# Altium schematic sheet Inresol_grid_inverter_L3.SchDoc(Inresol_grid_inverter_L3)
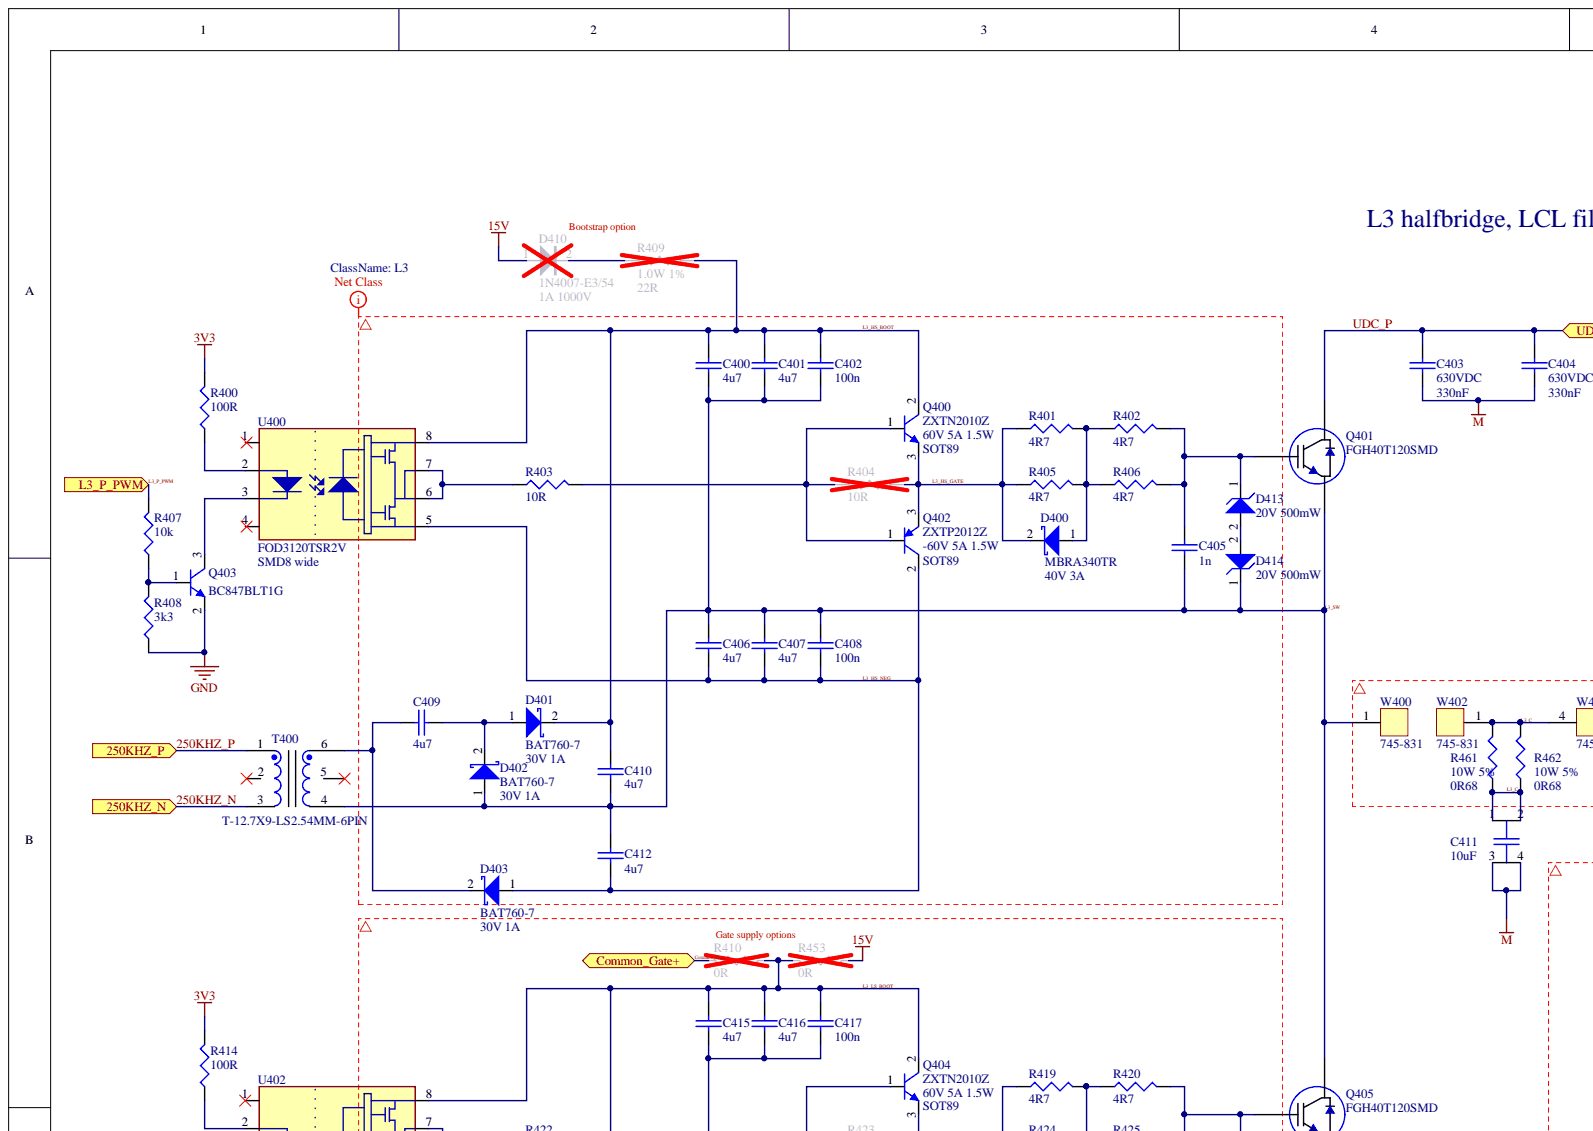
[diagram p0: Inresol_grid_inverter_L3.SchDoc, top left]
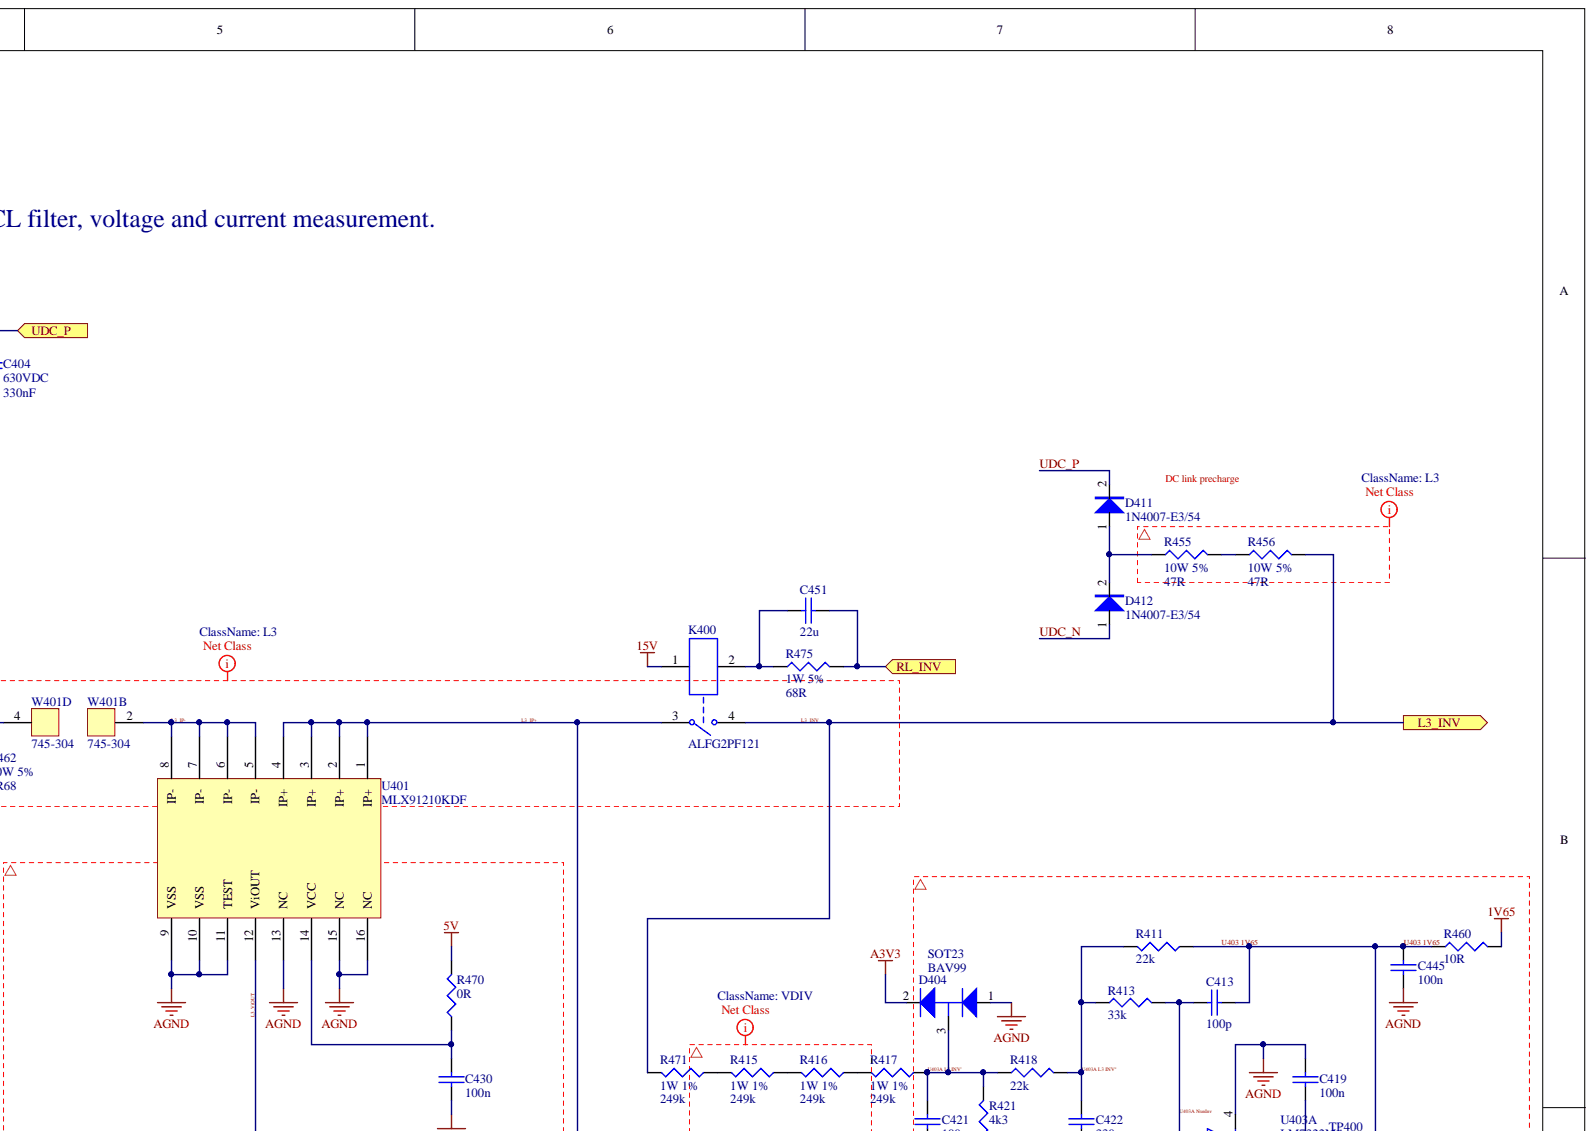
[diagram p1: Inresol_grid_inverter_L3.SchDoc, top right]
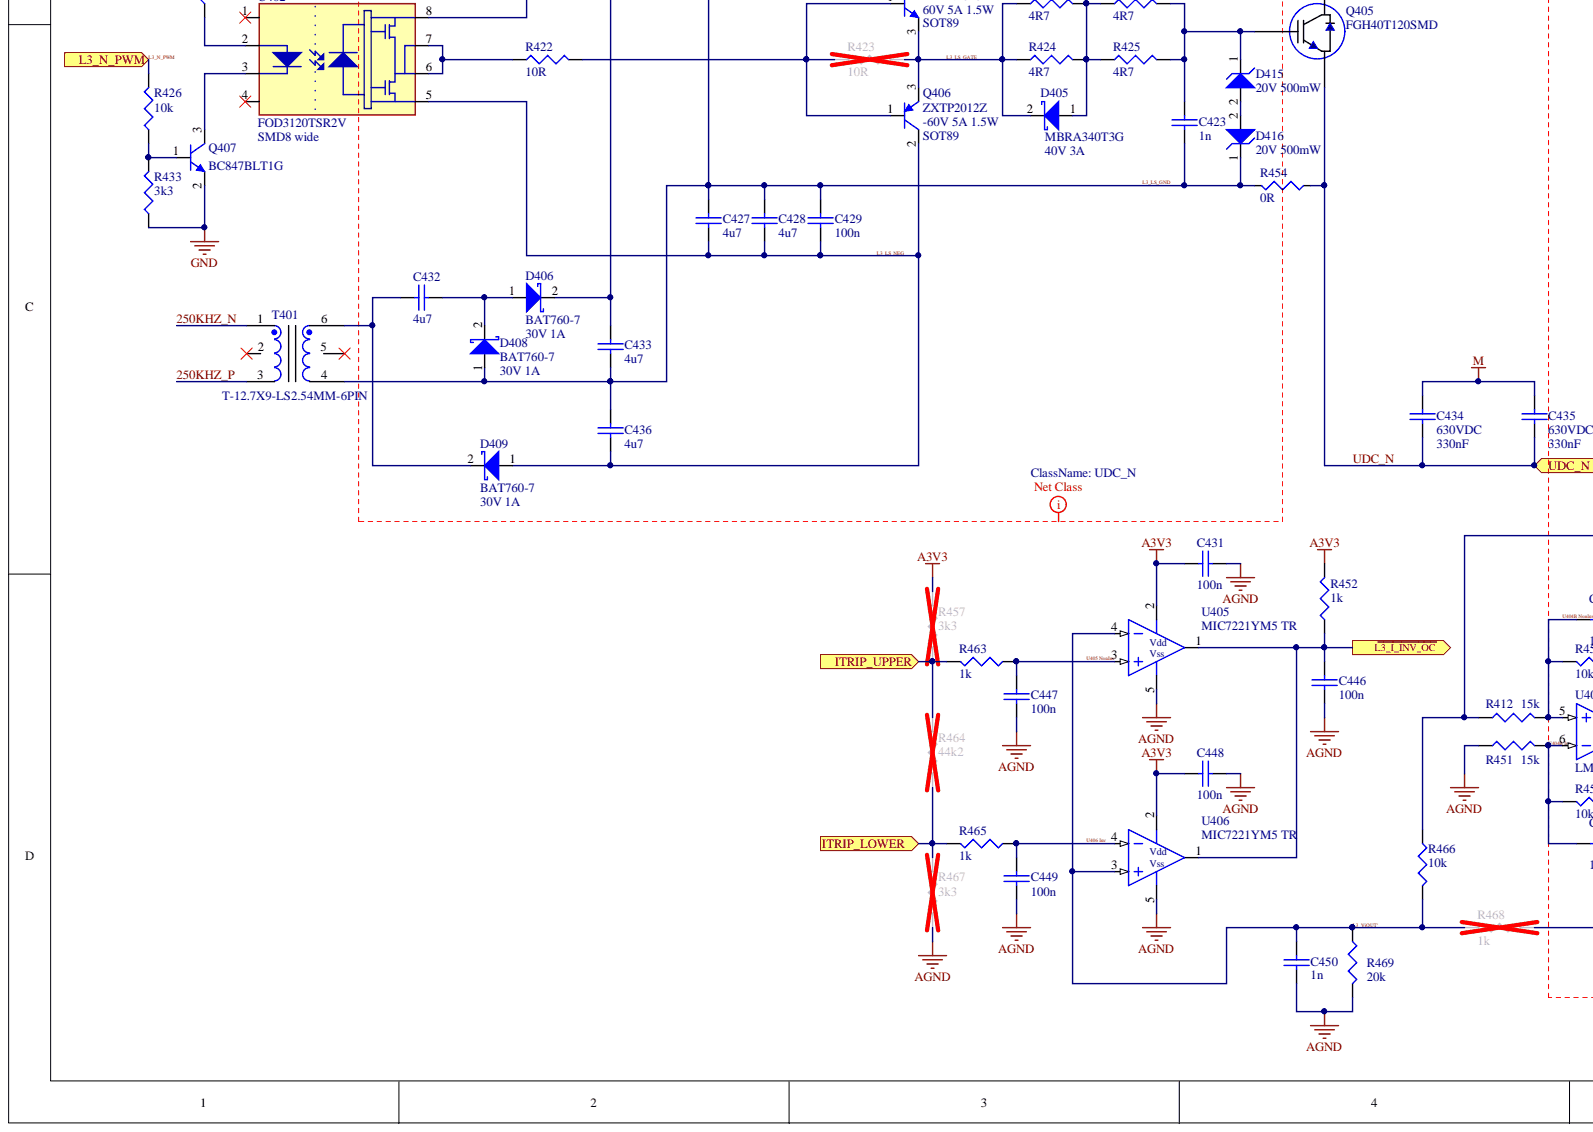
[diagram p2: Inresol_grid_inverter_L3.SchDoc, bottom left]
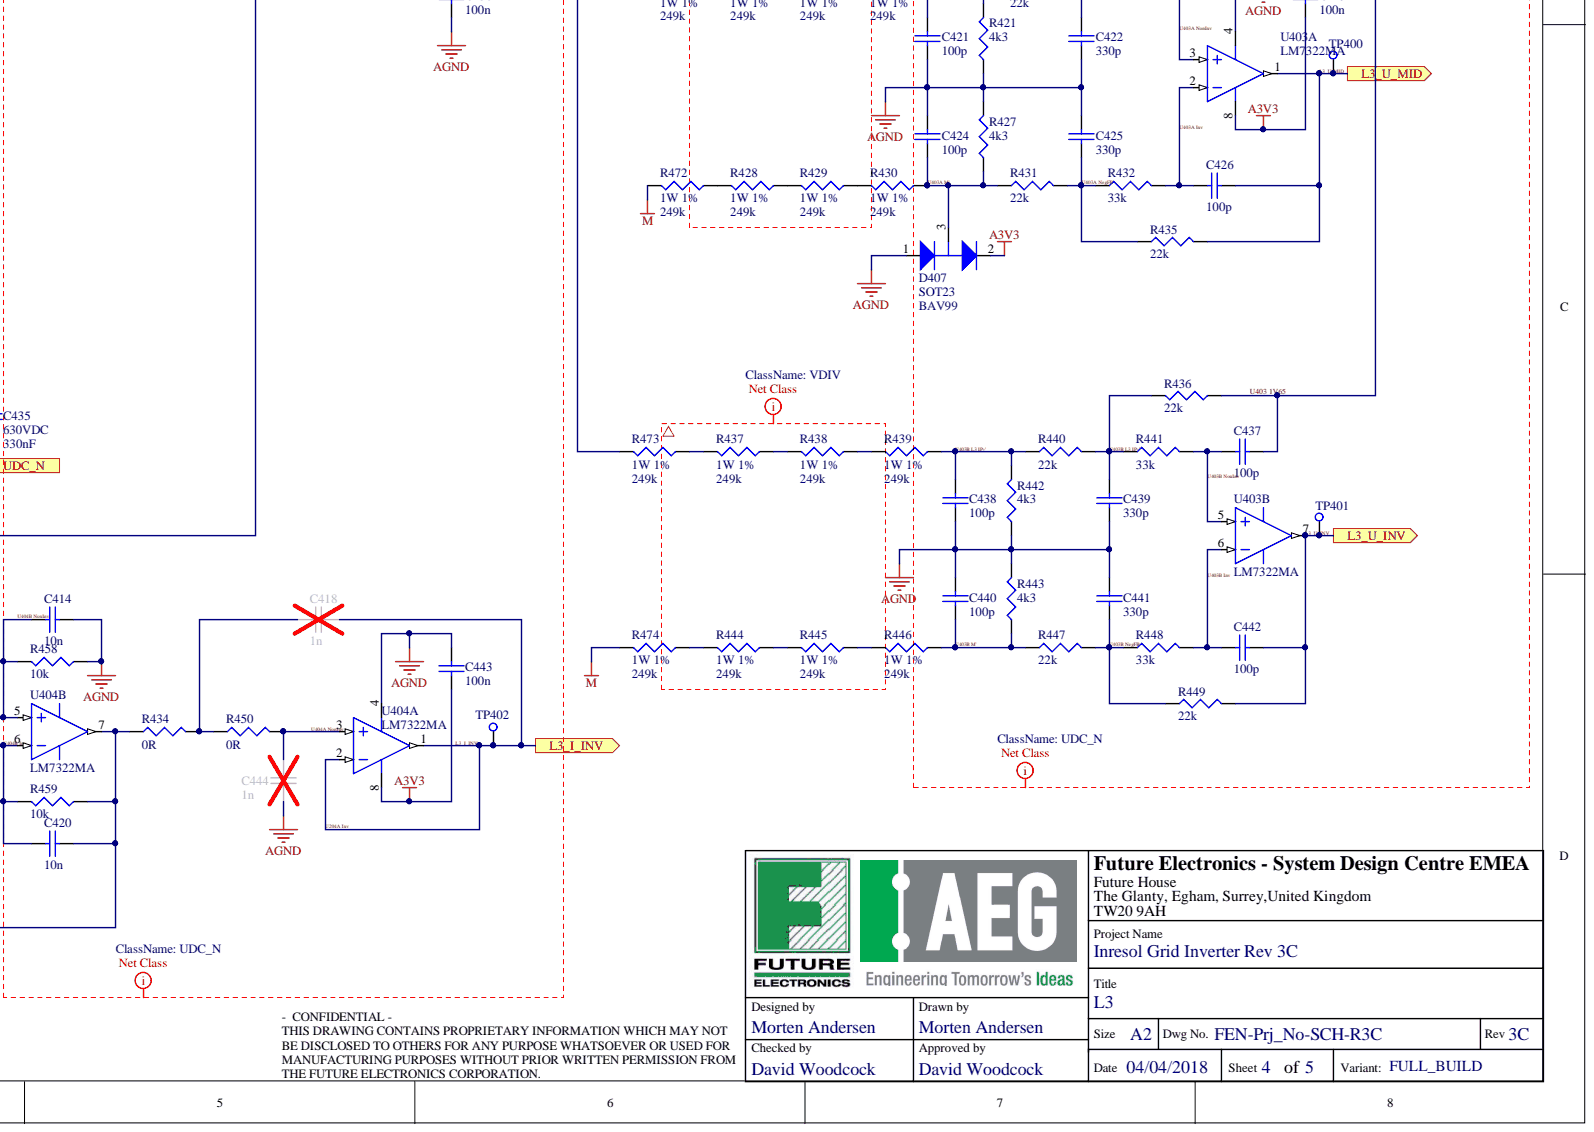
[diagram p3: Inresol_grid_inverter_L3.SchDoc, bottom right]

## Parts
C400  value 4u7  [1206]  (hidden comment: C-4.7uF-50V-Cer-1206-emea-8-10-15)
C401  value 4u7  [1206]  (hidden comment: C-4.7uF-50V-Cer-1206-emea-8-10-15)
C402  value 100n  [0603]  (hidden comment: C-100nF-50V-Cer-0603)
C403  value 330nF  Rating: 630VDC  [C-18x8.5_LS15]  (hidden comment: C-330nF-630V-PolyF-17.5x8.5)
C404  value 330nF  Rating: 630VDC  [C-18x8.5_LS15]  (hidden comment: C-330nF-630V-PolyF-17.5x8.5)
C405  value 1n  [0603]  (hidden comment: C-1nF-50V-Cer-0603)
C406  value 4u7  [1206]  (hidden comment: C-4.7uF-50V-Cer-1206-emea-8-10-15)
C407  value 4u7  [1206]  (hidden comment: C-4.7uF-50V-Cer-1206-emea-8-10-15)
C408  value 100n  [0603]  (hidden comment: C-100nF-50V-Cer-0603)
C409  value 4u7  [1206]  (hidden comment: C-4.7uF-50V-Cer-1206-emea-8-10-15)
C410  value 4u7  [1206]  (hidden comment: C-4.7uF-50V-Cer-1206-emea-8-10-15)
C411  value 10uF  (hidden comment: C-10uF-275V-PolyF-C-43x21.5)
C412  value 4u7  [1206]  (hidden comment: C-4.7uF-50V-Cer-1206-emea-8-10-15)
C413  value 100p  [0603]  (hidden comment: C-100pF-25V-Cer-0603)
C414  value 10n  [0603]  (hidden comment: C-10nF-25V-Cer-0603)
C415  value 4u7  [1206]  (hidden comment: C-4.7uF-50V-Cer-1206-emea-8-10-15)
C416  value 4u7  [1206]  (hidden comment: C-4.7uF-50V-Cer-1206-emea-8-10-15)
C417  value 100n  [0603]  (hidden comment: C-100nF-50V-Cer-0603)
C418  value 1n  [0603]  (hidden comment: C-1nF-50V-Cer-0603)
C419  value 100n  [0603]  (hidden comment: C-100nF-50V-Cer-0603)
C420  value 10n  [0603]  (hidden comment: C-10nF-25V-Cer-0603)
C421  value 100p  [0603]  (hidden comment: C-100pF-25V-Cer-0603)
C422  value 330p  [0603]  (hidden comment: C-330pF-25V-Cer-0603)
C423  value 1n  [0603]  (hidden comment: C-1nF-50V-Cer-0603)
C424  value 100p  [0603]  (hidden comment: C-100pF-25V-Cer-0603)
C425  value 330p  [0603]  (hidden comment: C-330pF-25V-Cer-0603)
C426  value 100p  [0603]  (hidden comment: C-100pF-25V-Cer-0603)
C427  value 4u7  [1206]  (hidden comment: C-4.7uF-50V-Cer-1206-emea-8-10-15)
C428  value 4u7  [1206]  (hidden comment: C-4.7uF-50V-Cer-1206-emea-8-10-15)
C429  value 100n  [0603]  (hidden comment: C-100nF-50V-Cer-0603)
C430  value 100n  [0603]  (hidden comment: C-100nF-50V-Cer-0603)
C431  value 100n  [0603]  (hidden comment: C-100nF-50V-Cer-0603)
C432  value 4u7  [1206]  (hidden comment: C-4.7uF-50V-Cer-1206-emea-8-10-15)
C433  value 4u7  [1206]  (hidden comment: C-4.7uF-50V-Cer-1206-emea-8-10-15)
C434  value 330nF  Rating: 630VDC  [C-18x8.5_LS15]  (hidden comment: C-330nF-630V-PolyF-17.5x8.5)
C435  value 330nF  Rating: 630VDC  [C-18x8.5_LS15]  (hidden comment: C-330nF-630V-PolyF-17.5x8.5)
C436  value 4u7  [1206]  (hidden comment: C-4.7uF-50V-Cer-1206-emea-8-10-15)
C437  value 100p  [0603]  (hidden comment: C-100pF-25V-Cer-0603)
C438  value 100p  [0603]  (hidden comment: C-100pF-25V-Cer-0603)
C439  value 330p  [0603]  (hidden comment: C-330pF-25V-Cer-0603)
C440  value 100p  [0603]  (hidden comment: C-100pF-25V-Cer-0603)
C441  value 330p  [0603]  (hidden comment: C-330pF-25V-Cer-0603)
C442  value 100p  [0603]  (hidden comment: C-100pF-25V-Cer-0603)
C443  value 100n  [0603]  (hidden comment: C-100nF-50V-Cer-0603)
C444  value 1n  [0603]  (hidden comment: C-1nF-50V-Cer-0603)
C445  value 100n  [0603]  (hidden comment: C-100nF-50V-Cer-0603)
C446  value 100n  [0603]  (hidden comment: C-100nF-50V-Cer-0603)
C447  value 100n  [0603]  (hidden comment: C-100nF-50V-Cer-0603)
C448  value 100n  [0603]  (hidden comment: C-100nF-50V-Cer-0603)
C449  value 100n  [0603]  (hidden comment: C-100nF-50V-Cer-0603)
C450  value 1n  [0603]  (hidden comment: C-1nF-50V-Cer-0603)
C451  value 22u  [1210]  (hidden comment: C-22uF-25V-Cer-1210)
D400  Future PN (TR): MBRA340TR  Rating: 40V 3A  [SMA]  (hidden comment: D-SMA-MBRA340T3G)
D401  MFR PN: BAT760-7  [SOD323]  (hidden comment: D-SOD323-BAT760-7)
D402  MFR PN: BAT760-7  Rating: 30V 1A  [SOD323]  (hidden comment: D-SOD323-BAT760-7)
D403  MFR PN: BAT760-7  Rating: 30V 1A  [SOD323]  (hidden comment: D-SOD323-BAT760-7)
D404  value BAV99  [SOT23]  (hidden comment: D-SOT23-BAV99)
D405  MFR PN: MBRA340T3G  Rating: 40V 3A  [SMA]  (hidden comment: D-SMA-MBRA340T3G)
D406  MFR PN: BAT760-7  [SOD323]  (hidden comment: D-SOD323-BAT760-7)
D407  value BAV99  [SOT23]  (hidden comment: D-SOT23-BAV99)
D408  MFR PN: BAT760-7  Rating: 30V 1A  [SOD323]  (hidden comment: D-SOD323-BAT760-7)
D409  MFR PN: BAT760-7  Rating: 30V 1A  [SOD323]  (hidden comment: D-SOD323-BAT760-7)
D410  MFR PN: 1N4007-E3/54  Rating: 1A 1000V  [DO-41]  (hidden comment: D-DO41-1N4007)
D411  MFR PN: 1N4007-E3/54  [DO-41]  (hidden comment: D-DO41-1N4007)
D412  MFR PN: 1N4007-E3/54  [DO-41]  (hidden comment: D-DO41-1N4007)
D413  Rating: 20V 500mW  [SOD123]  (hidden comment: D-SOD123-MMSZ5250B-20V-EMEA-19-05-16)
D414  Rating: 20V 500mW  [SOD123]  (hidden comment: D-SOD123-MMSZ5250B-20V-EMEA-19-05-16)
D415  Rating: 20V 500mW  [SOD123]  (hidden comment: D-SOD123-MMSZ5250B-20V-EMEA-19-05-16)
D416  Rating: 20V 500mW  [SOD123]  (hidden comment: D-SOD123-MMSZ5250B-20V-EMEA-19-05-16)
K400  MFR PN: ALFG2PF121  (hidden comment: K-Panasonic-Relay-SPST-NO-35A-12V-EMEA-07-09-2017)
Q400  value ZXTN2010Z  Future PN (CT): ZXTN2010Z  [SOT89]  (hidden comment: Q-SOT89-ZXTN2010Z)
Q401  MFR PN: FGH40T120SMD  [TO247]  (hidden comment: Q-TO-247-FGH40T120)
Q402  value ZXTP2012Z  MFR PN: ZXTP2012Z  [SOT89]  (hidden comment: Q-SOT89-ZXTP2012Z)
Q403  value BC847BLT1G  MFR PN: BC847BLT1G  [SOT23]  (hidden comment: Q-SOT23-BC847BLT1G)
Q404  value ZXTN2010Z  Future PN (CT): ZXTN2010Z  [SOT89]  (hidden comment: Q-SOT89-ZXTN2010Z)
Q405  MFR PN: FGH40T120SMD  [TO247]  (hidden comment: Q-TO-247-FGH40T120)
Q406  value ZXTP2012Z  MFR PN: ZXTP2012Z  [SOT89]  (hidden comment: Q-SOT89-ZXTP2012Z)
Q407  value BC847BLT1G  MFR PN: BC847BLT1G  [SOT23]  (hidden comment: Q-SOT23-BC847BLT1G)
R400  value 100R  [0603]  (hidden comment: R-100R-100mW-0603)
R401  value 4R7  [2512]  (hidden comment: R-4.7R-1W-2512)
R402  value 4R7  [2512]  (hidden comment: R-4.7R-1W-2512)
R403  value 10R  [2512]  (hidden comment: R-10R-1W-2512-EMEA-07-09-2017)
R404  value 10R  [2512]  (hidden comment: R-10R-1W-2512-EMEA-07-09-2017)
R405  value 4R7  [2512]  (hidden comment: R-4.7R-1W-2512)
R406  value 4R7  [2512]  (hidden comment: R-4.7R-1W-2512)
R407  value 10k  [0603]  (hidden comment: R-10k-100mW-0603)
R408  value 3k3  [0603]  (hidden comment: R-3.3k-100mW-0603)
R409  value 22R  Rating: 1.0W 1%  [2512]  (hidden comment: R-22R-1.0W-2512-EMEA)
R410  value 0R  [1206]  (hidden comment: R-0R-250mW-1206)
R411  value 22k  [0603]  (hidden comment: R-22k-100mW-0603)
R412  value 15k  [0603]  (hidden comment: R-15k-100mW-0603)
R413  value 33k  [0603]  (hidden comment: R-33k-100mW-0603)
R414  value 100R  [0603]  (hidden comment: R-100R-100mW-0603)
R415  value 249k  Rating: 1W 1%  [2512]  (hidden comment: R-249k-1W-2512)
R416  value 249k  Rating: 1W 1%  [2512]  (hidden comment: R-249k-1W-2512)
R417  value 249k  Rating: 1W 1%  [2512]  (hidden comment: R-249k-1W-2512)
R418  value 22k  [0603]  (hidden comment: R-22k-100mW-0603)
R419  value 4R7  [2512]  (hidden comment: R-4.7R-1W-2512)
R420  value 4R7  [2512]  (hidden comment: R-4.7R-1W-2512)
R421  value 4k3  [0603]  (hidden comment: R-4.3k-100mW-0603)
R422  value 10R  [2512]  (hidden comment: R-10R-1W-2512-EMEA-07-09-2017)
R423  value 10R  [2512]  (hidden comment: R-10R-1W-2512-EMEA-07-09-2017)
R424  value 4R7  [2512]  (hidden comment: R-4.7R-1W-2512)
R425  value 4R7  [2512]  (hidden comment: R-4.7R-1W-2512)
R426  value 10k  [0603]  (hidden comment: R-10k-100mW-0603)
R427  value 4k3  [0603]  (hidden comment: R-4.3k-100mW-0603)
R428  value 249k  Rating: 1W 1%  [2512]  (hidden comment: R-249k-1W-2512)
R429  value 249k  Rating: 1W 1%  [2512]  (hidden comment: R-249k-1W-2512)
R430  value 249k  Rating: 1W 1%  [2512]  (hidden comment: R-249k-1W-2512)
R431  value 22k  [0603]  (hidden comment: R-22k-100mW-0603)
R432  value 33k  [0603]  (hidden comment: R-33k-100mW-0603)
R433  value 3k3  [0603]  (hidden comment: R-3.3k-100mW-0603)
R434  value 0R  [0603]  (hidden comment: R-0R-100mW-0603)
R435  value 22k  [0603]  (hidden comment: R-22k-100mW-0603)
R436  value 22k  [0603]  (hidden comment: R-22k-100mW-0603)
R437  value 249k  Rating: 1W 1%  [2512]  (hidden comment: R-249k-1W-2512)
R438  value 249k  Rating: 1W 1%  [2512]  (hidden comment: R-249k-1W-2512)
R439  value 249k  Rating: 1W 1%  [2512]  (hidden comment: R-249k-1W-2512)
R440  value 22k  [0603]  (hidden comment: R-22k-100mW-0603)
R441  value 33k  [0603]  (hidden comment: R-33k-100mW-0603)
R442  value 4k3  [0603]  (hidden comment: R-4.3k-100mW-0603)
R443  value 4k3  [0603]  (hidden comment: R-4.3k-100mW-0603)
R444  value 249k  Rating: 1W 1%  [2512]  (hidden comment: R-249k-1W-2512)
R445  value 249k  Rating: 1W 1%  [2512]  (hidden comment: R-249k-1W-2512)
R446  value 249k  Rating: 1W 1%  [2512]  (hidden comment: R-249k-1W-2512)
R447  value 22k  [0603]  (hidden comment: R-22k-100mW-0603)
R448  value 33k  [0603]  (hidden comment: R-33k-100mW-0603)
R449  value 22k  [0603]  (hidden comment: R-22k-100mW-0603)
R450  value 0R  [0603]  (hidden comment: R-0R-100mW-0603)
R451  value 15k  [0603]  (hidden comment: R-15k-100mW-0603)
R452  value 1k  [0603]  (hidden comment: R-1k-100mW-0603)
R453  value 0R  [1206]  (hidden comment: R-0R-250mW-1206)
R454  value 0R  [1206]  (hidden comment: R-0R-250mW-1206)
R455  value 47R  Rating: 10W 5%  [13x9]  (hidden comment: R-47R-10W-13x9x51-EMEA-19-01-2016)
R456  value 47R  Rating: 10W 5%  [13x9]  (hidden comment: R-47R-10W-13x9x51-EMEA-19-01-2016)
R457  value 3k3  [0603]  (hidden comment: R-3.3k-100mW-0603)
R458  value 10k  [0603]  (hidden comment: R-10k-100mW-0603)
R459  value 10k  [0603]  (hidden comment: R-10k-100mW-0603)
R460  value 10R  [0603]  (hidden comment: R-10R-100mW-0603)
R461  value 0R68  Rating: 10W 5%  [13x9]  (hidden comment: R-0R68-10W-13x9x51-EMEA-07-09-2017)
R462  value 0R68  Rating: 10W 5%  [13x9]  (hidden comment: R-0R68-10W-13x9x51-EMEA-07-09-2017)
R463  value 1k  [0603]  (hidden comment: R-1k-100mW-0603)
R464  value 44k2  [0603]  (hidden comment: R-44.2k-100mW -0603)
R465  value 1k  [0603]  (hidden comment: R-1k-100mW-0603)
R466  value 10k  [0603]  (hidden comment: R-10k-100mW-0603)
R467  value 3k3  [0603]  (hidden comment: R-3.3k-100mW-0603)
R468  value 1k  [0603]  (hidden comment: R-1k-100mW-0603)
R469  value 20k  [0603]  (hidden comment: R-20k-100mW-0603)
R470  value 0R  [0603]  (hidden comment: R-0R-100mW-0603)
R471  value 249k  Rating: 1W 1%  [2512]  (hidden comment: R-249k-1W-2512)
R472  value 249k  Rating: 1W 1%  [2512]  (hidden comment: R-249k-1W-2512)
R473  value 249k  Rating: 1W 1%  [2512]  (hidden comment: R-249k-1W-2512)
R474  value 249k  Rating: 1W 1%  [2512]  (hidden comment: R-249k-1W-2512)
R475  value 68R  Rating: 1W 5%  [2512]  (hidden comment: R-68R-1W-2512)
T400  Custom  [T-12.7X9-LS2.54MM-6PIN]  (value not drawn)
T401  Custom  [T-12.7X9-LS2.54MM-6PIN]  (value not drawn)
TP400  TP-TEST POINT-LOOP WT-EMEA-15-10-15  [SMD test point round]  (value not drawn)  (no BOM)
TP401  TP-TEST POINT-LOOP WT-EMEA-15-10-15  [SMD test point round]  (value not drawn)  (no BOM)
TP402  TP-TEST POINT-LOOP WT-EMEA-15-10-15  [SMD test point round]  (value not drawn)  (no BOM)
U400  value FOD3120TSR2V  MFR PN: FOD3120TSR2V  [SMD8 wide]  (hidden comment: U-SMD8 wide -FOD3120SD)
U401  Rating: MLX91210KDF  [SOIC16W]  (hidden comment: U- SOICW 16PIN-MLX91210KDF-EMEA-24-08-2017)
U402  value FOD3120TSR2V  MFR PN: FOD3120TSR2V  [SMD8 wide]  (hidden comment: U-SMD8 wide -FOD3120SD)
U403  value LM7322MA  MFR PN: LM7322MA  [SO8]  (hidden comment: U-SOIC8-LM7322MA-EMEA)  (parts A,B)
U404  value LM7322MA  MFR PN: LM7322MA  [SO8]  (hidden comment: U-SOIC8-LM7322MA-EMEA)  (parts A,B)
U405  U-SOT23_5-MIC7221YM5 TR  [SOT23_5]  (value not drawn)
U406  U-SOT23_5-MIC7221YM5 TR  [SOT23_5]  (value not drawn)
W400  J-WAGO_745-831  [745-831]  (value not drawn)
W401  J-WAGO_745-304_blocks  [745-304]  (value not drawn)  (parts B,D)
W402  J-WAGO_745-831  [745-831]  (value not drawn)

## Ports
250KHZ_N, 250KHZ_P, COMMON_GATE+, ITRIP_LOWER, ITRIP_UPPER, L3_I_INV, L3_INV, L3_N_PWM, L3_P_PWM, L3_U_INV, L3_U_MID, RL_INV, UDC_N, UDC_P, ~{L3_I_INV_OC}

## Nets
1V65: R460.2
3V3: R400.2 R414.2
5V: R470.2
15V: D410.1 K400.1 R453.1
A3V3: C419.2 C431.1 C443.2 C448.1 D404.2 D407.2 R452.2 R457.2 U403.8 U404.8 U405.2 U406.2
AGND: C414.2 C419.1 C421.2 C422.2 C424.1 C425.1 C430.2 C431.2 C438.2 C439.2 C440.1 C441.1 C443.1 C444.2 C445.2 C446.1 C447.1 C448.2 C449.1 C450.1 D404.1 D407.1 R421.1 R427.2 R442.1 R443.2 R451.1 R458.1 R467.1 R469.2 U401.9(VSS) U401.10(VSS) U401.11(TEST) U401.13(NC) U401.15(NC) U401.16(NC) U403.4 U404.4 U405.5 U406.5
GND: Q403.2 Q407.2 R408.1 R433.1
M: C403.2 C404.2 C411.3 C411.4 C434.2 C435.2 R472.2 R474.2
250KHZ_N: T400.3 T401.1 ; port 250KHZ_N
250KHZ_P: T400.1 T401.3 ; port 250KHZ_P
COMMON_GATE+: R410.2 ; port COMMON_GATE+
ITRIP_LOWER: R464.1 R465.2 R467.2 ; port ITRIP_LOWER
ITRIP_UPPER: R457.1 R463.2 R464.2 ; port ITRIP_UPPER
L3_C: R461.2 R462.2 W401.4 W402.1
L3_C_: C411.1 C411.2 R461.1 R462.1
L3_HS_BOOT: C400.2 C401.2 C402.1 C410.2 D401.2 Q400.2 R409.2 U400.8
L3_HS_GATE: D400.2 Q400.3 Q402.3 R401.1 R404.2 R405.1
L3_HS_NEG: C406.1 C407.1 C408.2 C412.1 D403.1 Q402.2 U400.5
L3_I_INV: C418.2 TP402.1 U404.1 U404.2 ; port L3_I_INV
L3_INV: K400.4 R456.2 R471.2 ; port L3_INV
L3_IP+: K400.3 R473.2 U401.1(IP+) U401.2(IP+) U401.3(IP+) U401.4(IP+)
L3_IP-: U401.5(IP-) U401.6(IP-) U401.7(IP-) U401.8(IP-) W401.2
L3_LS_BOOT: C415.2 C416.2 C417.1 C433.2 D406.2 Q404.2 R410.1 R453.2 U402.8
L3_LS_GATE: D405.2 Q404.3 Q406.3 R419.1 R423.2 R424.1
L3_LS_GND: C415.1 C416.1 C417.2 C423.2 C427.2 C428.2 C429.1 C433.1 C436.2 D408.1 D416.1 R454.2 T401.4
L3_LS_NEG: C427.1 C428.1 C429.2 C436.1 D409.1 Q406.2 U402.5
L3_N_PWM: R426.2 ; port L3_N_PWM
L3_P_PWM: R407.2 ; port L3_P_PWM
L3_SW: C400.1 C401.1 C402.2 C405.2 C406.2 C407.2 C408.1 C410.1 C412.2 D402.1 D414.1 Q401.3 Q405.2 T400.4 W400.1
L3_U_INV: C442.1 R449.2 TP401.1 U403.7 ; port L3_U_INV
L3_U_MID: C426.1 R435.2 TP400.1 U403.1 ; port L3_U_MID
L3_VIOUT: R412.1 R466.1 U401.12(ViOUT)
L3_VIOUT': C450.2 R466.2 R468.1 R469.1 U405.4 U406.3
~{L3_I_INV_OC}: C446.2 R452.1 U405.1 U406.1 ; port ~{L3_I_INV_OC}
RL_INV: C451.2 R475.2 ; port RL_INV
U403 1V65: C413.1 C437.1 C445.1 R411.1 R436.1 R460.1
U403A INV: C426.2 R432.2 U403.2
U403A L3 INV': C421.1 D404.3 R417.1 R418.1 R421.2
U403A L3 INV'': C422.1 R411.2 R413.1 R418.2
U403A M': C424.2 D407.3 R427.1 R430.1 R431.1
U403A NEGFB: C425.2 R431.2 R432.1 R435.1
U403A NONINV: C413.2 R413.2 U403.3
U403B INV: C442.2 R448.2 U403.6
U403B L3 IP+': C438.1 R439.1 R440.1 R442.2
U403B L3 IP+'': C439.1 R436.2 R440.2 R441.1
U403B M': C440.2 R443.1 R446.1 R447.1
U403B NEGFB: C441.2 R447.2 R448.1 R449.1
U403B NONINV: C437.2 R441.2 U403.5
U404A NONINV: C444.1 R450.2 U404.3
U404B INV: C420.1 R451.2 R459.2 U404.6
U404B NONINV: C414.1 R412.2 R458.2 U404.5
U405 NONINV: C447.2 R463.1 U405.3
U406 INV: C449.2 R465.1 U406.4
UDC_N: C434.1 C435.1 D412.1 Q405.3 R454.1 ; port UDC_N
UDC_P: C403.1 C404.1 D411.2 Q401.2 ; port UDC_P
NetC405_1: C405.1 D413.1 Q401.1 R402.2 R406.2
NetC409_1: C409.1 D403.2 T400.6
NetC409_2: C409.2 D401.1 D402.2
NetC418_1: C418.1 R434.2 R450.1
NetC420_2: C420.2 R434.1 R459.1 R468.2 U404.7
NetC423_1: C423.1 D415.1 Q405.1 R420.2 R425.2
NetC430_1: C430.1 R470.1 U401.14(VCC)
NetC432_1: C432.1 D409.2 T401.6
NetC432_2: C432.2 D406.1 D408.2
NetC451_1: C451.1 K400.2 R475.1
NetD400_1: D400.1 R401.2 R402.1 R405.2 R406.1
NetD405_1: D405.1 R419.2 R420.1 R424.2 R425.1
NetD410_2: D410.2 R409.1
NetD411_1: D411.1 D412.2 R455.1
NetD413_2: D413.2 D414.2
NetD415_2: D415.2 D416.2
NetQ400_1: Q400.1 Q402.1 R403.2 R404.1
NetQ403_1: Q403.1 R407.1 R408.2
NetQ403_3: Q403.3 U400.3
NetQ404_1: Q404.1 Q406.1 R422.2 R423.1
NetQ407_1: Q407.1 R426.1 R433.2
NetQ407_3: Q407.3 U402.3
NetR400_1: R400.1 U400.2
NetR403_1: R403.1 U400.6 U400.7
NetR414_1: R414.1 U402.2
NetR415_1: R415.1 R416.2
NetR415_2: R415.2 R471.1
NetR416_1: R416.1 R417.2
NetR422_1: R422.1 U402.6 U402.7
NetR428_1: R428.1 R429.2
NetR428_2: R428.2 R472.1
NetR429_1: R429.1 R430.2
NetR437_1: R437.1 R438.2
NetR437_2: R437.2 R473.1
NetR438_1: R438.1 R439.2
NetR444_1: R444.1 R445.2
NetR444_2: R444.2 R474.1
NetR445_1: R445.1 R446.2
NetR455_2: R455.2 R456.1
N00399:
N00400:
N00401:
N00402:
N00403:
N00404:
N00405:
N00406:

Not connected: T400 2 5; T401 2 5; U400 1 4; U402 1 4

## Library data of the parts
C400 C401 C406 C407 C409 C410 C412 C415 C416 C427 C428 C432 C433 C436 = C-4.7uF-50V-Cer-1206-emea-8-10-15: Cap 1206 SM X7R 50V 10%; MFR: SAMSUNG; MFR PN: CL31B475KBHNNNE; Apr'd: raised emea 8th Oct 2015; Future PN (CT): TBA; Future PN (TR): 12065C475KATM; Rating: 50V 10%; Component Kind: Standard; ComponentLink1Description: Datasheet; ComponentLink1URL: http://www.samsungsem.com/servlet/ProductSearchView?page=1&searchIdx=1&lang=kr&partnum=1&find_val=CL31B475KBHNNNE; Library Name: EMEA CAPACITORS_FEB2016.SchLib
C402 C408 C417 C419 C429-C431 C443 C445-C449 = C-100nF-50V-Cer-0603: Cap 0603 SM X7R 50V 10%; MFR: AVX; MFR PN: 06035C104K4T2A; Future PN (CT): 06035C104K4TN-CT; Future PN (TR): 06035C104K4TN; Rating: 50V 10%; Component Kind: Standard; ComponentLink1Description: Datasheet; ComponentLink1URL: http://www.avx.com/docs/Catalogs/cx7r.pdf; Library Name: EMEA CAPACITORS_FEB2016.SchLib; Verified: AD030615
C403 C404 C434 C435 = C-330nF-630V-PolyF-17.5x8.5-EMEA-07-09-2017: Cap 17.5x8.5 TH Polyprop 630VDC; MFR: VISHAY; MFR PN: BFC233820334; Apr'd: TBD; Future PN (CT): TBA; Component Kind: Standard; ComponentLink1Description: Datasheet; ComponentLink1URL: https://www.vishay.com/docs/28119/mkp3382.pdf; Future PN (TR): TBD; Library Name: Inresol_grid_inverter.SchLib
C405 C418 C423 C444 C450 = C-1nF-50V-Cer-0603: Cap 0603 SM X7R 50V 10%; MFR: VISHAY; MFR PN: VJ0603Y102KXACW1BC; Future PN (CT): 06035C102KATN-CT; Future PN (TR): 06035C102KATN; Rating: 50V 10%; Component Kind: Standard; ComponentLink1Description: Datasheet; ComponentLink1URL: http://www.vishay.com/docs/28548/vjw1bcbascomseries.pdf; Library Name: Future Capacitors.SCHLIB; Verified: AD030615
C411 = C-10uF-275V-PolyF-C-43x21.5: Cap 43x21.5 TH Polyprop 275V 5%; MFR: Vishay; MFR PN: MKP1847610274P4; Future PN (CT): TBD; Rating: 275Vac 5%; Component Kind: Standard; ComponentLink1Description: Datasheet; ComponentLink1URL: http://www.vishay.com/docs/28172/mkp1847ac.pdf; Future PN (TR): TBD; Library Name: Inresol_grid_inverter.SchLib
C413 C421 C424 C426 C437 C438 C440 C442 = C-100pF-25V-Cer-0603: Cap 0603 SM X7R 25V 10%; MFR: AVX; MFR PN: 06033C101KAT2A; Future PN (CT): 06033C101KATN-CT; Future PN (TR): 06033C101KATN; Rating: 25V 10%; Component Kind: Standard; ComponentLink1Description: Datasheet; ComponentLink1URL: http://www.avx.com/docs/Catalogs/cx7r.pdf; Library Name: Future Capacitors.SCHLIB; Verified: AD030615
C414 C420 = C-10nF-25V-Cer-0603: Cap 0603 SM X7R 25V 10%; MFR: AVX; MFR PN: 06033C103KAT2A; Future PN (CT): 06033C103KATN-CT; Future PN (TR): 06033C103KATN; Rating: 25V 10%; Component Kind: Standard; ComponentLink1Description: Datasheet; ComponentLink1URL: http://www.avx.com/docs/Catalogs/ccog.pdf; Library Name: Future Capacitors.SCHLIB
C422 C425 C439 C441 = C-330pF-25V-Cer-0603: Cap 0603 SM X7R 25V 1%; MFR: AVX; MFR PN: 06033A331FAT2A; Future PN (CT): TBD; Future PN (TR): 06033A331FATN; Rating: 25V 1%; Component Kind: Standard; ComponentLink1Description: Datasheet; ComponentLink1URL: http://www.avx.com/docs/Catalogs/ccog.pdf; Library Name: Future Capacitors.SCHLIB; Verified: AD030615
C451 = C-22uF-25V-Cer-1210: Cap 1210 SM X7R 25V 10%; MFR: MURATA; MFR PN: GRM32ER71E226KE15L; Future PN (CT): TBD; Future PN (TR): 12103C226KATM; Rating: 25V 10%; Component Kind: Standard; ComponentLink1Description: Datasheet; ComponentLink1URL: http://search.murata.co.jp/Ceramy/image/img/A01X/partnumbering_e_01.pdf; Library Name: Future Capacitors.SCHLIB; Verified: AD030615
D400 = D-SMA-MBRA340T3G: Diode Schottky 40V 3A SMD; MFR: On Semi; Apr'd: YES; Future PN (CT): MBRA340TR-CT; Component Kind: Standard; ComponentLink1Description: Datasheet; ComponentLink1URL: http://www.onsemi.com/pub_link/Collateral/MBRA340T3-D.PDF; Library Name: EMEA SEMICONDUCTORS_FEB2016.SchLib; MFR PN: MBRA340T3G
D401 D406 = D-SOD323-BAT760-7: Diode Schottky 30V 1A SMD; MFR: DIO; Future PN (CT): TBD; Rating: 30V 1A; Component Kind: Standard; ComponentLink1Description: Datasheet; ComponentLink1URL: https://www.diodes.com/assets/Datasheets/ds30498.pdf; Future PN (TR): TBD; Library Name: Inresol_grid_inverter.SchLib
D402 D403 D408 D409 = D-SOD323-BAT760-7: Diode Schottky 30V 1A SMD; MFR: DIO; Future PN (CT): TBD; Component Kind: Standard; ComponentLink1Description: Datasheet; ComponentLink1URL: https://www.diodes.com/assets/Datasheets/ds30498.pdf; Future PN (TR): TBD; Library Name: Inresol_grid_inverter.SchLib
D404 D407 = D-SOT23-BAV99: Diode, Silicon Dual connected in series SOT23; MFR: DIO; Future PN (CT): BAV99LT1-CT; Future PN (TR): BAV99-7-F; Rating: 75V 300mA; Component Kind: Standard; ComponentLink1Description: Datasheet; ComponentLink1URL: http://www.diodes.com/datasheets/ds12007.pdf; Library Name: Future Semiconductors.SCHLIB; MFR PN: BAV99-7-F
D405 = D-SMA-MBRA340T3G: Diode Schottky 40V 3A SMD; MFR: On Semi; Apr'd: YES; Future PN (CT): MBRA340TR-CT; Future PN (TR): MBRA340TR; Component Kind: Standard; ComponentLink1Description: Datasheet; ComponentLink1URL: http://www.onsemi.com/pub_link/Collateral/MBRA340T3-D.PDF; Library Name: EMEA SEMICONDUCTORS_FEB2016.SchLib
D410-D412 = D-DO41-1N4007: Diode Switching Si 1A 1000V; MFR: VISHAY; Apr'd: YES; Future PN (CT): 1N4007TR-CT; Future PN (TR): 1N4007TR; Component Kind: Standard; ComponentLink1Description: Datasheet; ComponentLink1URL: http://www.vishay.com/docs/88503/1n4001.pdf; Library Name: Future Semiconductors.SCHLIB
  D411 D412: Rating: 1A 1000V
D413-D416 = D-SOD123-MMSZ5250B-20V-EMEA-19-05-16: Diode Zener 20V 500mW; MFR: DIODES INC; Apr'd: RAISED EMEA 19-05-16; Future PN (CT): TBD; Component Kind: Standard; ComponentLink1Description: Datasheet; ComponentLink1URL: http://www.farnell.com/datasheets/1670964.pdf; Future PN (TR): TBD; Library Name: EMEA SEMICONDUCTORS_FEB2016.SchLib; MFR PN: MMSZ5250B; Signal Integrity: D Zener; Simulation: ZENER; Value: MMSZ5250B
K400 = K-Panasonic-Relay-SPST-NO-35A-12V-EMEA-07-09-2017: K-Relay, SPST-NO 35A 12VDC; Future PN (CT): TBD; Rating: SPST-NO 12VDC, 250VAC 31A; Component Kind: Standard; ComponentLink1Description: Datasheet; ComponentLink1URL: http://www3.panasonic.biz/ac/e/search_num/index.jsp?c=detail&part_no=ALFG2PF121; Future PN (TR): TBD; MFR: Panasonic; Simulation: SPSTRELAY; Library Name: Inresol_grid_inverter.SchLib
Q400 Q404 = Q-SOT89-ZXTN2010Z: Transistor NPN, 60V 5A 1.5W SOT89-EMEA29-09-2016; MFR: DIODES; Apr'd: YES; Future PN (TR): TBD; Component Kind: Standard; ComponentLink1Description: Datasheet; ComponentLink1URL: http://www.diodes.com/_files/datasheets/ZXTN2010Z.pdf; MFR PN: ZXTN2010Z; Rating: 60V 5A 1.5W; Library Name: Inresol_grid_inverter.SchLib
Q401 Q405 = Q-TO-247-FGH40T120: TRENCH IGBT-FGH40T120-EMEA28-09-2016; MFR: Fairchild; Apr'd: RAISED EMEA 20TH SEPT 2016; Future PN (CT): TBA; Rating: 1200V; Value: 40A; Component Kind: Standard; ComponentLink1Description: Datasheet; ComponentLink1URL: https://www.fairchildsemi.com/datasheets/FG/FGH40T120SMD.pdf; Future PN (TR): TBA; Library Name: Inresol_grid_inverter.SchLib
Q402 Q406 = Q-SOT89-ZXTP2012Z: Transistor PNP, -60V -5A 1.5W SOT89-EMEA29-09-2016; MFR: DIODES; Apr'd: YES; Future PN (CT): TBD; Rating: -60V 5A 1.5W; Component Kind: Standard; ComponentLink1Description: Datasheet; ComponentLink1URL: http://www.diodes.com/_files/datasheets/ZXTP2012Z.pdf; Future PN (TR): TBD; Library Name: Inresol_grid_inverter.SchLib
Q403 Q407 = Q-SOT23-BC847BLT1G: Transistor NPN SW, 45V 100mA SOT23; MFR: ON SEMI; Apr'd: YES; Future PN (CT): BC847BLT1-CT; Component Kind: Standard; ComponentLink1Description: Datasheet; ComponentLink1URL: http://www.onsemi.com/pub_link/Collateral/BC846ALT1-D.PDF; Future PN (TR): BC847BLT1; Library Name: Future Semiconductors.SCHLIB; Rating: 45V 100mA; Signal Integrity: MMBT2222A; Simulation: QMMBT2222A
R400 R414 = R-100R-100mW-0603: Res 0603 SM 100mW 1% 100ppm; MFR: YAGEO; MFR PN: RC0603FR-07100RL; Future PN (CT): CR0603-1000FTR-CT; Future PN (TR): CR0603-1000FTR; Component Kind: Standard; ComponentLink1Description: Datasheet; ComponentLink1URL: http://www.yageo.com/documents/recent/PYu-RC0603_51_RoHS_L_4.pdf; Library Name: Future Resistors.SCHLIB; Rating: 100mW 1%
R401 R402 R405 R406 R419 R420 R424 R425 = R-4.7R-1W-2512: Res 2512 SM 5W 1% 200ppm; MFR: STACKPOLE; MFR PN: RPC2512JT4R70; Future PN (CT): TBD; Rating: 1W 5%; Component Kind: Standard; ComponentLink1Description: Datasheet; ComponentLink1URL: http://www.yageo.com/documents/recent/PYu-RC1206_51_RoHS_L_4.pdf; Future PN (TR): TBD; Library Name: Future Resistors.SCHLIB
R403 R404 R422 R423 = R-10R-1W-2512-EMEA-07-09-2017: Res 2512 SM 1W 5%; MFR: YAGEO; MFR PN: RC2512JK-0710RL; Future PN (CT): TBD; Rating: 1W 1%; Component Kind: Standard; ComponentLink1Description: Datasheet; ComponentLink1URL: http://www.yageo.com/documents/recent/PYu-RC2512_51_RoHS_L_4.pdf; Future PN (TR): TBD; Library Name: Inresol_grid_inverter.SchLib
R407 R426 R458 R459 R466 = R-10k-100mW-0603: Res 0603 SM 100mW 1% 100ppm; MFR: YAGEO; MFR PN: RC0603FR-0710KL; Future PN (CT): CR0603-1002FTR-CT; Future PN (TR): CR0603-1002FTR; Component Kind: Standard; ComponentLink1Description: Datasheet; ComponentLink1URL: http://www.yageo.com/documents/recent/PYu-RC0603_51_RoHS_L_4.pdf; Library Name: Future Resistors.SCHLIB; Rating: 100mW 1%
R408 R433 R457 R467 = R-3.3k-100mW-0603: Res 0603 SM 100mW 1% 100ppm; MFR: YAGEO; MFR PN: RC0603FR-073K3L; Future PN (CT): CR0603-3301FTR-CT; Future PN (TR): CR0603-3301FTR; Component Kind: Standard; ComponentLink1Description: Datasheet; ComponentLink1URL: http://www.yageo.com/documents/recent/PYu-RC0603_51_RoHS_L_4.pdf; Library Name: Future Resistors.SCHLIB; Rating: 100mW 1%
R409 = R-22R-1.0W-2512-EMEA: Res 2512 SM 1.0W 1% 100ppm; MFR: VISHAY; MFR PN: CRCW251222R0FKEG; Apr'd: RAISED EMEA 4TH FEB 2013; Future PN (CT): TBD; Future PN (TR): CR2512-22R0FTR; Component Kind: Standard; ComponentLink1Description: Datasheet; ComponentLink1URL: http://www.vishay.com/docs/20043/crcwhpe3.pdf; Library Name: EMEA RESISTORS_FEB2016.SchLib; Signal Integrity: Res; Simulation: RESISTOR
R410 R453 R454 = R-0R-250mW-1206: Res 1206 SM 250mW; MFR: YAGEO; MFR PN: RC1206JR-070RL; Future PN (CT): CR1206-0000JTR-CT; Future PN (TR): CR1206-0000JTR; Component Kind: Standard; ComponentLink1Description: Datasheet; ComponentLink1URL: http://www.yageo.com/documents/recent/PYu-RC1206_51_RoHS_L_4.pdf; Library Name: Future Resistors.SCHLIB; Rating: 250mW
R411 R418 R431 R435 R436 R440 R447 R449 = R-22k-100mW-0603: Res 0603 SM 100mW 1% 100ppm; MFR: YAGEO; MFR PN: RC0603FR-0722KL; Future PN (CT): CR0603-2202FTR-CT; Future PN (TR): CR0603-2202FTR; Component Kind: Standard; ComponentLink1Description: Datasheet; ComponentLink1URL: http://www.yageo.com/documents/recent/PYu-RC0603_51_RoHS_L_4.pdf; Library Name: Future Resistors.SCHLIB; Rating: 100mW 1%
R412 R451 = R-15k-100mW-0603: Res 0603 SM 100mW 1% 100ppm; MFR: YAGEO; MFR PN: RC0603FR-0715KL; Future PN (CT): CR0603-1502FTR-CT; Future PN (TR): CR0603-1502FTR; Component Kind: Standard; ComponentLink1Description: Datasheet; ComponentLink1URL: http://www.yageo.com/pdf/yageo/Yu-RC0603_51_PbFree_L_2.pdf; Library Name: Future Resistors.SCHLIB; Rating: 100mW 1%
R413 R432 R441 R448 = R-33k-100mW-0603: Res 0603 SM 100mW 1% 100ppm; MFR: YAGEO; MFR PN: RC0603FR-0733KL; Future PN (CT): CR0603-3302FTR-CT; Future PN (TR): CR0603-3302FTR; Component Kind: Standard; ComponentLink1Description: Datasheet; ComponentLink1URL: http://www.yageo.com/documents/recent/PYu-RC0603_51_RoHS_L_4.pdf; Library Name: Future Resistors.SCHLIB; Rating: 100mW 1%
R415-R417 R428-R430 R437-R439 R444-R446 R471-R474 = R-249k-1W-2512-EMEA-25-08-2017: Res 2512 249k SM 1W 1%; MFR: Vishay; MFR PN: CRCW2512249KFKEG; Future PN (CT): TBD; Component Kind: Standard; ComponentLink1Description: Datasheet; ComponentLink1URL: http://www.vishay.com/doc?20035; Future PN (TR): TBD; Library Name: Inresol_grid_inverter.SchLib
R421 R427 R442 R443 = R-4.3k-100mW-0603: Res 0603 SM 100mW 1% 100ppm; MFR: YAGEO; MFR PN: RC0603FR-074K3L; Future PN (CT): CR0603-4301FTR-CT; Future PN (TR): CR0603-4301FTR; Component Kind: Standard; ComponentLink1Description: Datasheet; ComponentLink1URL: http://www.yageo.com/pdf/yageo/Yu-RC0603_51_PbFree_L_2.pdf; Library Name: Future Resistors.SCHLIB; Rating: 100mW 1%
R434 R450 R470 = R-0R-100mW-0603: Res 0603 SM 100mW; MFR: YAGEO; MFR PN: RC0603JR-070RL; Future PN (CT): CR0603-0000JTR-R-CT; Future PN (TR): CR0603-0000JTR-R; Component Kind: Standard; ComponentLink1Description: Datasheet; ComponentLink1URL: http://www.rohm.com/products/databook/r/pdf/mcr03.pdf; Library Name: Future Resistors.SCHLIB; Rating: 100mW
R452 R463 R465 R468 = R-1k-100mW-0603: Res 0603 SM 100mW 1% 100ppm; MFR: YAGEO; MFR PN: RC0603FR-071KL; Future PN (CT): CR0603-1001FTR-CT; Future PN (TR): CR0603-1001FTR; Component Kind: Standard; ComponentLink1Description: Datasheet; ComponentLink1URL: http://www.yageo.com/documents/recent/PYu-RC0603_51_RoHS_L_4.pdf; Library Name: EMEA RESISTORS_FEB2016.SchLib; Rating: 100mW 1%
R455 R456 = R-47R-10W-13x9x51-EMEA-19-01-2016: Res 13x9x51 TH 10W 5% WW; MFR: TE; MFR PN: SQMW1047RJ; Future PN (CT): TBD; Component Kind: Standard; ComponentLink1Description: Datasheet; ComponentLink1URL: http://www.te.com/usa-en/product-1623785-4.html; Future PN (TR): TBD; Library Name: Inresol_grid_inverter.SchLib
R460 = R-10R-100mW-0603: Res 0603 SM 100mW 1% 100ppm; MFR: YAGEO; MFR PN: RC0603FR-0710RL; Future PN (CT): CR0603-10R0FTR-CT; Future PN (TR): CR0603-10R0FTR; Component Kind: Standard; ComponentLink1Description: Datasheet; ComponentLink1URL: http://www.yageo.com/documents/recent/PYu-RC0603_51_RoHS_L_4.pdf; Library Name: Future Resistors.SCHLIB; Rating: 100mW 1%
R461 R462 = R-0R68-10W-13x9x51-EMEA-07-09-2017: Res 13x9x51 TH 10W 5% WW; MFR: TE; MFR PN: SQMW10R68J; Future PN (CT): TBD; Component Kind: Standard; ComponentLink1Description: Datasheet; ComponentLink1URL: http://www.te.com/usa-en/product-1623785-4.html; Future PN (TR): TBD; Library Name: Inresol_grid_inverter.SchLib
R464 = R-44.2k-100mW -0603: Res 0603 SM 100mW 1% 100ppm; MFR: VISHAY; MFR PN: CRCW060344K2FKEA; Future PN (CT): CR0603-4422FTR-CT; Future PN (TR): CR0603-4422FTR; Component Kind: Standard; ComponentLink1Description: Datasheet; ComponentLink1URL: http://www.vishay.com/docs/20035/dcrcwe3.pdf; Library Name: Future Resistors.SCHLIB; Rating: 100mW 1%
R469 = R-20k-100mW-0603: Res 0603 SM 100mW 1% 100ppm; MFR: YAGEO; MFR PN: RC0603FR-0720KL; Future PN (CT): CR0603-2002FTR-CT; Future PN (TR): CR0603-2002FTR; Component Kind: Standard; ComponentLink1Description: Datasheet; ComponentLink1URL: http://www.yageo.com/documents/recent/PYu-RC0603_51_RoHS_L_4.pdf; Library Name: Future Resistors.SCHLIB; Rating: 100mW 1%
R475 = R-68R-1W-2512-EMEA-20-12-2017: Res 2512 68R SM 1W 5%; MFR: YAGEO; MFR PN: RC2512JK-0768RL; Future PN (CT): TBD; Component Kind: Standard; ComponentLink1Description: Datasheet; ComponentLink1URL: http://www.futureelectronics.com/en/Search.aspx?dsNav=Ntk:PartNumberSearch%7CRC2512JK%2F-0768RL%7C1%7C,Ny:True,Ro:0,Nea:True; Future PN (TR): TBD; Library Name: Inresol_grid_inverter.SchLib
T400 T401 = T-Signal Transformer-78601/8JC: Custom Signal Transformer; MFR: Murata; Future PN (CT): TBD; Component Kind: Standard; ComponentLink1Description: Datasheet; ComponentLink1URL: http://www.murata-ps.com/datasheet?http://www.murata-ps.com/data/magnetics/kmp_786j.pdf; Future PN (TR): TBD; MFR PN: 78601/8JC; Library Name: Inresol_grid_inverter.SchLib
TP400-TP402 = TP-TEST POINT-LOOP WT-EMEA-15-10-15: Test Point; MFR: NA; Future PN (CT): NONE; Future PN (TR): TBD; ComponentLink1Description: Datasheet; Library Name: EMEA MISC_FEB2016.SchLib; MFR PN: NA; Signal Integrity: Connector
U400 U402 = U-SMD8 wide -FOD3120SD: IC, High Noise Immunity, 2.5 A Output Current,   Gate Drive Optocoupler, wideSMD8-EMEA29-09-2016; MFR: FAIRCHILD; Future PN (CT): TBD; Component Kind: Standard; ComponentLink1Description: Datasheet; ComponentLink1URL: https://www.fairchildsemi.com/datasheets/FO/FOD3120.pdf; Future PN (TR): TBD; Library Name: Inresol_grid_inverter.SchLib
U401 = U- SOICW 16PIN-MLX91210KDF-EMEA-24-08-2017: MLX91210KDF ISOLATED CURRENT SENSOR; MFR: Melexis; MFR PN: MLX91210KDF-CAS-102-RE; APPR'D: Raised EMEA 21/09/2016; ComponentLink1 Description: *Datasheet; Future PN (CT): *tba; Future PN (TR): tba; Component Kind: Standard; Componentlink1 URL: https://www.melexis.com/-/media/files/documents/datasheets/mlx91210-datasheet-melexis.pdf; Library Name: Inresol_grid_inverter.SchLib
U403 U404 = U-SOIC8-LM7322MA-EMEA_20122017: IC, Gen Purpose Dual OpAmp LM7322MA; MFR: TI; Future PN (TR): NA; Rating: 5V; Component Kind: Standard; ComponentLink1Description: Datasheet; ComponentLink1URL: http://uk.farnell.com/fairchild-semiconductor/lmv358am8x/ic-op-amp-1-4mhz-1-5v-us-soic-8/dp/1262552; Library Name: Inresol_grid_inverter.SchLib
U405 U406 = U-SOT23_5-MIC7221YM5 TR: IC, Rail to Rail Input Comparator wt Open-Drain Output; MFR: MICREL; Apr'd: YES; Future PN (CT): MIC7221BM5TR-CT; Future PN (TR): MIC7221BM5TR; Rating: 2-10V; Component Kind: Standard; ComponentLink1Description: Datasheet; ComponentLink1URL: http://www.micrel.com/_PDF/mic7211.pdf; Library Name: Future Semiconductors.SCHLIB; MFR PN: MIC7221YM5 TR
W400 W402 = J-WAGO_745-831: Connector WAGO 4 pin; Future PN (CT): TBD; Component Kind: Standard; ComponentLink1Description: Datasheet; ComponentLink1URL: http://www.wago.us/search/index.jsp?_ts=1479964580288&action=noresults&q=745-831&nav=top%2Fproductpath%3Asearch_productpath_label%C7%81f%2Fsearch_productpath_label%2F00005_pcb+terminal+blocks+and+connectors%2F00001_pcb+terminal+blocks%2F00007_pin+spacing+7.5+%26%2347%3B+7.62+mm%C7%81search_productpath_label%C7%8100007_Pin+spacing+7.5+%26%2347%3B+7.62+mm; Future PN (TR): TBD; MFR: WAGO; MFR PN: 745-831; Signal Integrity: Connector; Value: WAGO; Library Name: Inresol_grid_inverter.SchLib
W401 = J-WAGO_745-304_blocks: Connector WAGO 4 pin; Future PN (CT): TBD; Component Kind: Standard; ComponentLink1Description: Datasheet; ComponentLink1URL: http://www.wago.us/search/index.jsp?_ts=1479484242100&action=morearticledownloads&q=745-304; Future PN (TR): TBD; MFR: WAGO; MFR PN: 745-304; Signal Integrity: Connector; Value: WAGO; Library Name: Inresol_grid_inverter.SchLib
